# Altium schematic sheet AMS_Master.SchDoc(AMS_Master)
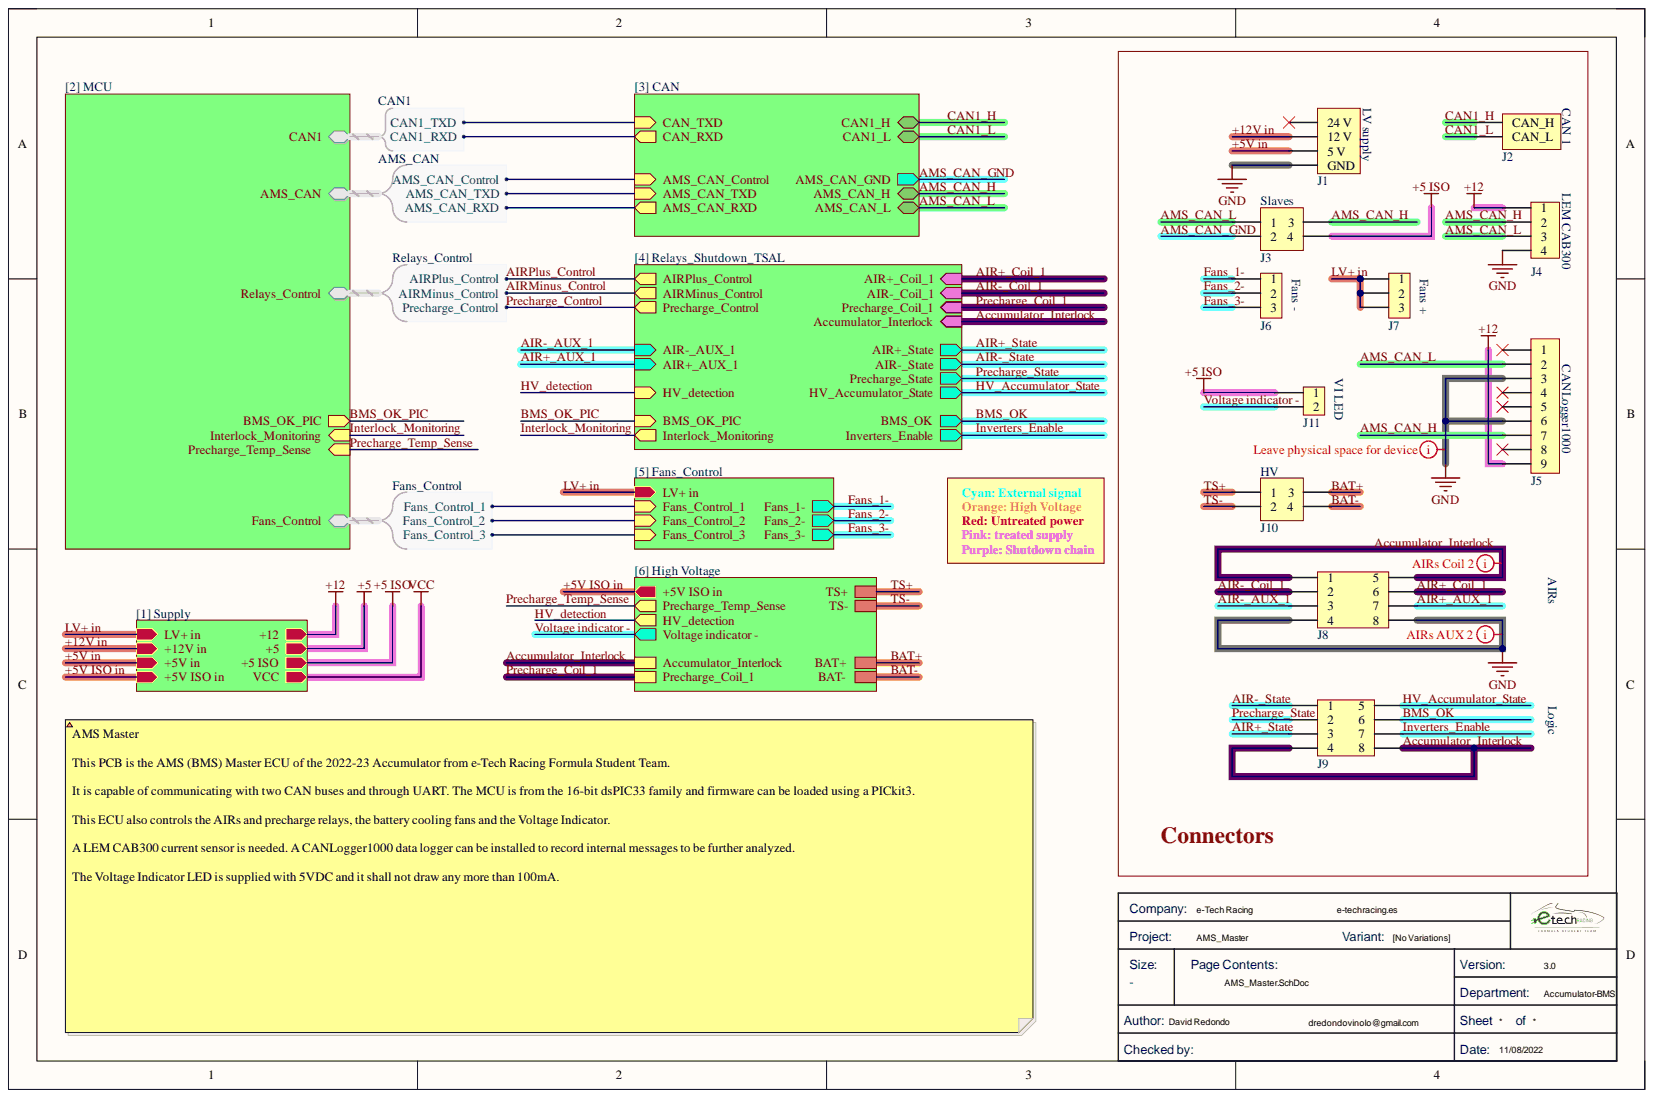
[diagram p0: AMS_Master.SchDoc]

## Parts
J1  1053141104  [J_NanoFit_2x2]  (value not drawn)
J2  430450200  [J_MicroFit_1x2]  (value not drawn)
J3  430450412  [J_MicroFit_2x2_v]  (value not drawn)
J4  1053092104  [J_NanoFit_1x4_V]  (value not drawn)
J5  J_DB9  [J_DB9]  (value not drawn)
J6  282836-3  [J_screw_1x3]  (value not drawn)
J7  282836-3  [J_screw_1x3]  (value not drawn)
J8  0430450800  [J_MicroFit_2x4]  (value not drawn)
J9  105314-1108  [J_NanoFit_2X4]  (value not drawn)
J10  430450412  [J_MicroFit_2x2_v]  (value not drawn)
J11  282836-2  [J_screw_1x2]  (value not drawn)

## Nets
+5 ISO: J3.4 J11.1
+12: J4.1 J5.9
GND: J1.1(GND) J4.4 J5.3 J5.6 J8.4 J8.8
+5V in: J1.2(5_V)
+12V in: J1.3(12_V)
Accumulator_Interlock: J8.1 J8.5 J9.4 J9.8
AIR+_AUX_1: J8.7
AIR+_Coil_1: J8.6
AIR+_State: J9.3
AIR-_AUX_1: J8.3
AIR-_Coil_1: J8.2
AIR-_State: J9.1
AMS_CAN_GND: J3.2
AMS_CAN_H: J3.3 J4.2 J5.7
AMS_CAN_L: J3.1 J4.3 J5.2
BAT+: J10.3
BAT-: J10.4
BMS_OK: J9.6
CAN1.CAN1_RXD: J2.1 J2.2  [also labelled CAN1_H, CAN1_L]
Fans_1-: J6.1
Fans_2-: J6.2
Fans_3-: J6.3
HV_Accumulator_State: J9.5
Inverters_Enable: J9.7
LV+ in: J7.1 J7.2 J7.3
Precharge_State: J9.2
TS+: J10.1
TS-: J10.2
Voltage indicator -: J11.2
NetJ1_4: J1.4(24_V)
NetJ5_1: J5.1
NetJ5_4: J5.4
NetJ5_5: J5.5
NetJ5_8: J5.8
+5:
+5V ISO in:
AIRMinus_Control:
AIRPlus_Control:
AMS_CAN.AMS_CAN_Control:
AMS_CAN.AMS_CAN_RXD:
AMS_CAN.AMS_CAN_TXD:
BMS_OK_PIC:
CAN1.CAN1_TXD:
Fans_Control.Fans_Control_1:
Fans_Control.Fans_Control_2:
Fans_Control.Fans_Control_3:
HV_detection:
Interlock_Monitoring:
Precharge_Coil_1:
Precharge_Control:
Precharge_Temp_Sense:
VCC:

## Library data of the parts
J1 = J_Supply: Nano-Fit Right Angle Header Through Hole 2.50mm Pitch Dual Row 4 Circuits Tin (Sn) Plating Black; Manufacturer: Molex; .Creator: GP; Category type: PCB header; Connections: 2x2; Maximum current: 7.5 A; Maximum voltage: 750 V AC; Temperature rating: -40° to +105°C; Application: Wire to board; Category: Connector; Component Kind: Standard; ComponentLink1Description: Datasheet; ComponentLink1URL: https://www.molex.com/molex/products/part-detail/pcb_headers/1053141104; ComponentLink2Description: Where buy it; ComponentLink3Description: http://www.farnell.com/datasheets/2551094.pdf?src-supplier=Farnell; ComponentLink3URL: http://www.farnell.com/datasheets/2551094.pdf?src-supplier=Farnell; ComponentLink4Description: http://www.farnell.com/datasheets/2551094.pdf?src-supplier=Newark; ComponentLink4URL: http://www.farnell.com/datasheets/2551094.pdf?src-supplier=Newark; Flammability: 94V-0; Library Name: Electromechanical.SchLib; Manufacturer part number: 1053141104; Mount: Through hole; Orientation: Right Angle; Pricing 1: 1=1,25000, 10=1,03600, 300=0,86330, 1200=0,69065, 5100=0,60432, 10200=0,57410 (USD); Product name: Nano-Fit; Stock 1: 3160; Supplier 1: Digi-Key; Supplier Part Number 1: WM16907-ND
J2 = J_CAN: Header 2 Row Right-Angle Snap-In Lock 2Pin Tin Plate SMT/Glow Wire Compatible; Manufacturer: Molex; .Creator: GP; Approvals: UL,CSA,TUV; Category type: PCB header; Connections: 2x1; Contact Resistance: 10mR; Current Rating: 5A; Gender: Male; Glow Wire Compliant: Compliant; Insulation Resistance: 1GR; Max Voltage Rating (DC): 250.25kV; Maximum current: 8.5 A; Maximum voltage: 600 V; Number of Circuits: 2; Pitch: 3mm; Product name: Micro-Fit; Temperature rating: -40° to +105°C; Voltage Rating: 600V; Voltage Rating (DC): 250V; Application: Wire to board; Case/Package: SMC; Category: Connector; Color: Black; Component Kind: Standard; ComponentLink1Description: Datasheet; ComponentLink1URL: https://www.molex.com/pdm_docs/sd/430450200_sd.pdf; ComponentLink2Description: Where buy it; ComponentLink3Description: https://datasheet.ciiva.com/14155/04402310-14155203.pdf?src-supplier=Distrelec; ComponentLink3URL: https://datasheet.ciiva.com/14155/04402310-14155203.pdf?src-supplier=Distrelec; ComponentLink4Description: https://datasheet.ciiva.com/5150/0430450200-pcb-headers-145770-5150407.pdf?src-supplier=Mouser; ComponentLink4URL: https://datasheet.ciiva.com/5150/0430450200-pcb-headers-145770-5150407.pdf?src-supplier=Mouser; ComponentLink5Description: https://datasheet.ciiva.com/19454/883270-19454006.pdf?src-supplier=Jameco; ComponentLink5URL: https://datasheet.ciiva.com/19454/883270-19454006.pdf?src-supplier=Jameco; ComponentLink6Description: https://datasheet.ciiva.com/11747/0900766b80027348-11747001.pdf?src-supplier=RSComponents; ComponentLink6URL: https://datasheet.ciiva.com/11747/0900766b80027348-11747001.pdf?src-supplier=RSComponents; ComponentLink7Description: https://datasheet.ciiva.com/36854/124280430450200-pcb-headers-36854331.pdf?src-supplier=Onlinecomponents.com; ComponentLink7URL: https://datasheet.ciiva.com/36854/124280430450200-pcb-headers-36854331.pdf?src-supplier=Onlinecomponents.com; ComponentLink8Description: https://datasheet.ciiva.com/16656/0430450200-pcb-headers-16656330.pdf?src-supplier=Avnet+Europe; ComponentLink8URL: https://datasheet.ciiva.com/16656/0430450200-pcb-headers-16656330.pdf?src-supplier=Avnet+Europe; ComponentLink9Description: https://datasheet.ciiva.com/10090/0430450200-pcb-headers-10090299.pdf?src-supplier=Avnet; ComponentLink9URL: https://datasheet.ciiva.com/10090/0430450200-pcb-headers-10090299.pdf?src-supplier=Avnet; ComponentLink10Description: https://datasheet.ciiva.com/1443/1859921-1443437.pdf?src-supplier=Element14; ComponentLink10URL: https://datasheet.ciiva.com/1443/1859921-1443437.pdf?src-supplier=Element14; Contact Gender: Male; Contact Material: Brass,Tin; Contact Plating: Tin; Diameter: 3mm; Flammability: 94V-0; Flammability Rating: UL94 V-0; Halogen Free: Low Halogen; Housing Color: Black; Housing Material: Thermoplastic; Lead Free: Lead Free; Library Name: Electromechanical.SchLib; Manufacturer part number: 430450200; Material: Thermoplastic; Max Operating Temperature: 105°C; Min Operating Temperature: -40°C; Mount: Through hole; Number of Contacts: 2; Number of Positions: 2; Number of Rows: 2; Orientation: Right Angle; Package Quantity: 3168; Packaging: Tray; Pricing 1: 1=1,12000, 10=0,92600, 80=0,77138, 3168=0,55921, 6336=0,53993, 12672=0,50136 (USD); Radiation Hardening: No; REACH SVHC: Unknown; RoHS: Yes; Row Spacing: 3mm; Stock 1: 14652; Supplier 1: Digi-Key; Supplier Part Number 1: WM1813-ND; Termination: Through Hole
J3 J10 = J_MicroFit_2x2: Header 2 Row Vertical Polarizing Peg 4 Pin Tin Plate SMT/Glow Wire Kinked Leads; Manufacturer 1: Molex; Manufacturer Part Number 1: 43045-0412; .Creator: DR; Category: Headers and Wire Housings; Component Kind: Standard; ComponentLink1Description: https://datasheet.ciiva.com/19462/883368-19462265.pdf?src-supplier=Jameco; ComponentLink1URL: https://datasheet.ciiva.com/19462/883368-19462265.pdf?src-supplier=Jameco; ComponentLink2Description: https://datasheet.ciiva.com/5158/0430450412-pcb-headers-142874-5158187.pdf?src-supplier=Mouser; ComponentLink2URL: https://datasheet.ciiva.com/5158/0430450412-pcb-headers-142874-5158187.pdf?src-supplier=Mouser; ComponentLink3Description: https://datasheet.ciiva.com/30095/176640430450412-pcb-headers-30095929.pdf?src-supplier=Onlinecomponents.com; ComponentLink3URL: https://datasheet.ciiva.com/30095/176640430450412-pcb-headers-30095929.pdf?src-supplier=Onlinecomponents.com; ComponentLink4Description: https://datasheet.ciiva.com/11747/0900766b800bd7d2-11747137.pdf?src-supplier=RSComponents; ComponentLink4URL: https://datasheet.ciiva.com/11747/0900766b800bd7d2-11747137.pdf?src-supplier=RSComponents; ComponentLink5Description: https://datasheet.ciiva.com/26916/getdatasheetpartid-253008-26916516.pdf?src-supplier=Verical; ComponentLink5URL: https://datasheet.ciiva.com/26916/getdatasheetpartid-253008-26916516.pdf?src-supplier=Verical; ComponentLink6Description: https://datasheet.ciiva.com/22637/1928349-22637530.pdf?src-supplier=Element14; ComponentLink6URL: https://datasheet.ciiva.com/22637/1928349-22637530.pdf?src-supplier=Element14; ComponentLink7Description: https://datasheet.ciiva.com/9468/0430450412-pcb-headers-9468485.pdf?src-supplier=Avnet; ComponentLink7URL: https://datasheet.ciiva.com/9468/0430450412-pcb-headers-9468485.pdf?src-supplier=Avnet; Library Name: Electromechanical.SchLib; Pricing 1: 1=1,24720, 10=1,02970, 80=0,85390, 2232=0,63810, 6696=0,59030, 11160=0,55550 (USD); RoHS: Yes; Stock 1: 54122; Supplier 1: Arrow Electronics; Supplier Part Number 1: 43045-0412
J4 = J_NanoFit_1x4_V_W: Conn HDR 4 POS 2.5mm Solder ST Thru-Hole 4 Terminal 1 Port Nano-Fit Tray; Manufacturer 1: Molex; Manufacturer Part Number 1: 105309-2104; .Creator: DR; Category: Headers and Wire Housings; Component Kind: Standard; Library Name: Electromechanical.SchLib; Pricing 1: 1=1,30000, 10=1,15000, 25=1,11000, 50=1,07000, 100=1,02000, 250=0,94400 (USD); RoHS: No; Stock 1: 1183; Supplier 1: Newark; Supplier Part Number 1: 44AJ4572
J5 = J_DB9: AMPLIMITE HD22 Board Mount Connectors: AMPL REC, HD20, R/A, 9P, S/L, .318 ; Region : VERICAL; Manufacturer 1: TE Connectivity AMP; Manufacturer Part Number 1: 2311765-1; .Creator: DR; Category: D-Sub Connectors; Component Kind: Standard; ComponentLink1Description: https://www.te.com/commerce/DocumentDelivery/DDEController?Action=srchrtrv&DocNm=2311765&DocType=Customer+Drawing&DocLang=English&src-supplier=Digi-Key; ComponentLink1URL: https://www.te.com/commerce/DocumentDelivery/DDEController?Action=srchrtrv&DocNm=2311765&DocType=Customer+Drawing&DocLang=English&src-supplier=Digi-Key; Library Name: Electromechanical.SchLib; Pricing 1: 1=2,08170, 10=1,72420, 100=1,45980, 500=1,18730, 1100=1,06580, 2200=1,04720, 5500=1,04110, 11000=0,95140 (USD); RoHS: Yes; Stock 1: 9940; Supplier 1: Arrow Electronics; Supplier Part Number 1: 2311765-1
J6 J7 = J_CON3x1: Connector, Terminal Block; Wire Receptacle; 3 Pos.; 16-30 AWG; 0.197 in. CL; Sid; .Creator: GP; Approvals: UL; Closed End: No (Without); Current: 13.5A; Interlock: Yes (With); Max Supply Voltage (AC): 300V; Number of Circuits: 3; Number of Levels: 1; Pitch: 5mm; Plating: Brass; Tail Length: 3.5mm; Thread Size: M3; Voltage Rating (AC): 250V; Wire Gauge (Max): 30AWG; Wire Gauge (Min): 16AWG; Category: Connector; Color: Green; Component Kind: Standard; ComponentLink1Description: Datasheet; ComponentLink1URL: https://html.alldatasheet.com/html-pdf/349315/MACOM/2-282836-3/153/1/2-282836-3.html; ComponentLink2Description: Where buy it; ComponentLink3Description: https://datasheet.ciiva.com/15169/getdatasheetpartid-155856-15169554.pdf?src-supplier=Verical; ComponentLink3URL: https://datasheet.ciiva.com/15169/getdatasheetpartid-155856-15169554.pdf?src-supplier=Verical; ComponentLink4Description: https://datasheet.ciiva.com/16305/eng-cs-1308389-eurostyle-terminal-blocks-0607-16305242.pdf?src-supplier=Future+Electronics; ComponentLink4URL: https://datasheet.ciiva.com/16305/eng-cs-1308389-eurostyle-terminal-blocks-0607-16305242.pdf?src-supplier=Future+Electronics; ComponentLink5Description: https://datasheet.ciiva.com/19460/750661-19460522.pdf?src-supplier=Jameco; ComponentLink5URL: https://datasheet.ciiva.com/19460/750661-19460522.pdf?src-supplier=Jameco; ComponentLink6Description: https://datasheet.ciiva.com/11757/0900766b8002dc8a-11757406.pdf?src-supplier=RSComponents; ComponentLink6URL: https://datasheet.ciiva.com/11757/0900766b8002dc8a-11757406.pdf?src-supplier=RSComponents; ComponentLink7Description: https://datasheet.ciiva.com/823/eng-cd-282836-h10-823445.pdf?src-supplier=Digi-Key; ComponentLink7URL: https://datasheet.ciiva.com/823/eng-cd-282836-h10-823445.pdf?src-supplier=Digi-Key; ComponentLink8Description: https://datasheet.ciiva.com/30106/eng-cs-1308389-eurostyle-terminal-blocks-0607-30106198.pdf?src-supplier=Onlinecomponents.com; ComponentLink8URL: https://datasheet.ciiva.com/30106/eng-cs-1308389-eurostyle-terminal-blocks-0607-30106198.pdf?src-supplier=Onlinecomponents.com; ComponentLink9Description: https://datasheet.ciiva.com/14606/70086276-14606907.pdf?src-supplier=Allied+Electronics; ComponentLink9URL: https://datasheet.ciiva.com/14606/70086276-14606907.pdf?src-supplier=Allied+Electronics; ComponentLink10Description: https://datasheet.ciiva.com/16649/eng-cs-1308389-eurostyle-terminal-blocks-0607-16649389.pdf?src-supplier=Avnet+Europe; ComponentLink10URL: https://datasheet.ciiva.com/16649/eng-cs-1308389-eurostyle-terminal-blocks-0607-16649389.pdf?src-supplier=Avnet+Europe; ComponentLink11Description: https://datasheet.ciiva.com/3141/eng-cs-1308389-eurostyle-terminal-blocks-0607-3141119.pdf?src-supplier=Avnet; ComponentLink11URL: https://datasheet.ciiva.com/3141/eng-cs-1308389-eurostyle-terminal-blocks-0607-3141119.pdf?src-supplier=Avnet; Connector Type: Receptacle; Contact Material: Brass; Contact Plating: Tin; Current Rating: 13.5A; Depth: 8.2mm; ELV: Compliant; Flammability Rating: UL94 V-0; Housing Color: Green; Housing Material: Polyamide; Insulation Material: Nylon; Lead Free: Lead Free; Length: 15mm; Library Name: Electromechanical.SchLib; Max Current Rating: 13.5A; Max Voltage Rating (AC): 300V; Mount: Through hole; Number of Contacts: 3; Number of Poles: 3; Number of Positions: 3; Number of Positions Per Level: 3; Number of Rows: 1; Orientation: Straight; Package Quantity: 200; Pricing 1: 5=0,97600, 125=0,86800, 375=0,76800, 1000=0,67600, 2000=0,62200 (USD); Radiation Hardening: No; REACH SVHC: Unknown; RMS Current (Irms): 13.5A; RoHS Compliant: Yes; Screw Flange: No (Without); Screwless Terminal Block: No (Without); Series: Buchanan; Side Stackable: Yes (With); Stock 1: 1; Supplier 1: RSComponents; Supplier Part Number 1: 3617673; Termination: Screw; Voltage: 300V; Voltage Rating: 300V; Width: 10mm; Wire/Cable Gauge: 16AWG; Working Voltage: 250V
J8 = J_MicroFit_2x4: Connector Wire to Board Header 8 Position 3mm Solder Right Angle Thru-Hole Tray; Manufacturer 1: Molex; .Creator: GP; Category: Headers and Wire Housings; Component Kind: Standard; Library Name: Electromechanical.SchLib; Manufacturer Part Number 1: 0430450800; Pricing 1: 1=2,57150, 10=2,34190, 25=2,14460, 50=2,00330, 100=1,89490, 500=1,75400 (USD); RoHS: Yes; Stock 1: 47494; Supplier 1: Arrow Electronics; Supplier Part Number 1: 43045-0800
J9 = J_NanoFit_2x4: Nano-Fit Right Angle Header Through Hole 2.50mm Pitch Dual Row 8 Circuits Tin (Sn) Plating Black; Manufacturer: Molex; .Creator: DO; Category type: PCB header; Connections: 2x4; Connector Type: Header,Male Pin; Maximum current: 7.5 A; Maximum voltage: 750 V AC; Number of Positions: 8; Temperature rating: -40° to +105°C; Application: Wire to board; Category: Connector; Component Kind: Standard; ComponentLink1Description: Datasheet; ComponentLink1URL: https://www.molex.com/molex/products/part-detail/pcb_headers/1053141104; ComponentLink2Description: Where buy it; ComponentLink3Description: http://www.farnell.com/datasheets/2551096.pdf?src-supplier=Newark; ComponentLink3URL: http://www.farnell.com/datasheets/2551096.pdf?src-supplier=Newark; ComponentLink4Description: https://www.molex.com/pdm_docs/sd/1053141104_sd.pdf?src-supplier=Digi-Key; ComponentLink4URL: https://www.molex.com/pdm_docs/sd/1053141104_sd.pdf?src-supplier=Digi-Key; Contact Material: Brass; Contact Plating: Tin; Contact Shape: Square; Fastening Type: Latch; Flammability: 94V-0; Insulation Color: Black; Insulation Material: Polymer; Library Name: Electromechanical.SchLib; Manufacturer part number: 105314-1108; Max Operating Temperature: 105°C; Min Operating Temperature: -40°C; Mount: Through hole; Number of Rows: 2; Orientation: Right Angle; Packaging: Tray; Pricing 1: 1=1,98000, 10=1,68600, 156=1,39308, 1092=1,09983, 4992=0,97518, 10140=0,95318 (USD); Product name: Nano-Fit; RoHS Compliant: Yes; Stock 1: 11021; Supplier 1: Digi-Key; Supplier Part Number 1: WM16909-ND; Termination: Solder
J11 = J_CON2x1: Connector, Terminal Block; Wire Receptacle; 2 Pos.; 16-30 AWG; 0.197 in. CL; Sid; Manufacturer 1: TE Connectivity; Category: Terminal Blocks; Component Kind: Standard; ComponentLink1Description: https://datasheet.ciiva.com/15169/getdatasheetpartid-155855-15169553.pdf?src-supplier=Verical; ComponentLink1URL: https://datasheet.ciiva.com/15169/getdatasheetpartid-155855-15169553.pdf?src-supplier=Verical; ComponentLink2Description: https://datasheet.ciiva.com/16393/282836-2-16393382.pdf?src-supplier=Future+Electronics; ComponentLink2URL: https://datasheet.ciiva.com/16393/282836-2-16393382.pdf?src-supplier=Future+Electronics; ComponentLink3Description: https://datasheet.ciiva.com/11757/0900766b8002dc8a-11757385.pdf?src-supplier=RSComponents; ComponentLink3URL: https://datasheet.ciiva.com/11757/0900766b8002dc8a-11757385.pdf?src-supplier=RSComponents; ComponentLink4Description: https://datasheet.ciiva.com/7628/1731782-7628513.pdf?src-supplier=Element14; ComponentLink4URL: https://datasheet.ciiva.com/7628/1731782-7628513.pdf?src-supplier=Element14; ComponentLink5Description: https://datasheet.ciiva.com/823/eng-cd-282836-h10-823437.pdf?src-supplier=Digi-Key; ComponentLink5URL: https://datasheet.ciiva.com/823/eng-cd-282836-h10-823437.pdf?src-supplier=Digi-Key; ComponentLink6Description: https://datasheet.ciiva.com/3141/eng-cs-1308389-eurostyle-terminal-blocks-0607-3141118.pdf?src-supplier=Avnet; ComponentLink6URL: https://datasheet.ciiva.com/3141/eng-cs-1308389-eurostyle-terminal-blocks-0607-3141118.pdf?src-supplier=Avnet; ComponentLink7Description: https://datasheet.ciiva.com/30261/eng-cs-1308389-eurostyle-terminal-blocks-0607-30261813.pdf?src-supplier=Onlinecomponents.com; ComponentLink7URL: https://datasheet.ciiva.com/30261/eng-cs-1308389-eurostyle-terminal-blocks-0607-30261813.pdf?src-supplier=Onlinecomponents.com; Library Name: Electromechanical.SchLib; Manufacturer Part Number 1: 282836-2; Pricing 1: 1=0,41710, 10=0,36230, 100=0,30770, 500=0,29220, 1000=0,26130, 2500=0,23640, 5000=0,21140, 10000=0,20690, 25000=0,20320 (USD); RoHS: Yes; Stock 1: 8391; Supplier 1: Arrow Electronics; Supplier Part Number 1: 282836-2
